# Altium schematic sheet driver4-8.SchDoc(driver4-8)
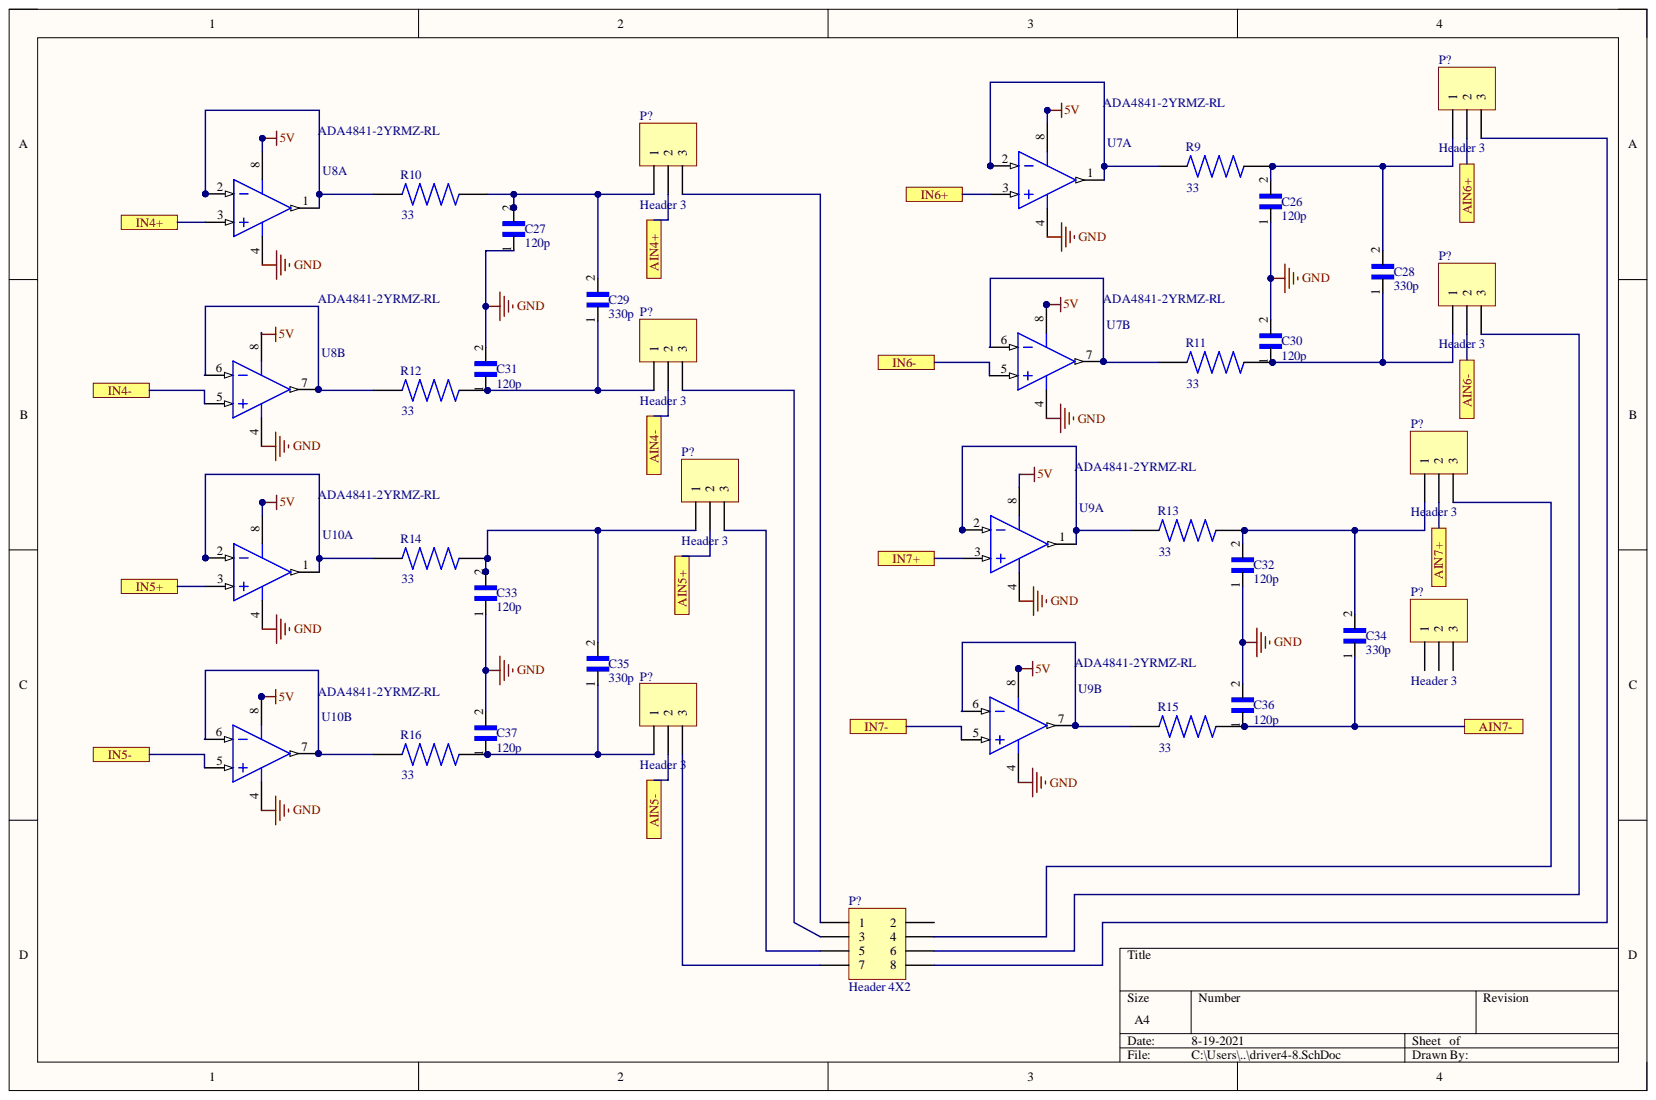
[diagram p0: driver4-8.SchDoc]

## Parts
C26  120p  [CAPC3216X94N]
C27  120p  [CAPC3216X94N]
C28  330p  [CAPC3216X94N]
C29  330p  [CAPC3216X94N]
C30  120p  [CAPC3216X94N]
C31  120p  [CAPC3216X94N]
C32  120p  [CAPC3216X94N]
C33  120p  [CAPC3216X94N]
C34  330p  [CAPC3216X94N]
C35  330p  [CAPC3216X94N]
C36  120p  [CAPC3216X94N]
C37  120p  [CAPC3216X94N]
P?  Header 3  [HDR1X3]
P?  Header 3  [HDR1X3]
P?  Header 3  [HDR1X3]
P?  Header 3  [HDR1X3]
P?  Header 3  [HDR1X3]
P?  Header 3  [HDR1X3]
P?  Header 3  [HDR1X3]
P?  Header 3  [HDR1X3]
P?  Header 4X2  [HDR2X4]
R9  33  [RESC3116X75N]
R10  33  [RESC3116X75N]
R11  33  [RESC3116X75N]
R12  33  [RESC3116X75N]
R13  33  [RESC3116X75N]
R14  33  [RESC3116X75N]
R15  33  [RESC3116X75N]
R16  33  [RESC3116X75N]
U7  ADA4841-2YRMZ-RL  [ADI-RM-8_M]  (parts A,B)
U8  ADA4841-2YRMZ-RL  [ADI-RM-8_M]  (parts A,B)
U9  ADA4841-2YRMZ-RL  [ADI-RM-8_M]  (parts A,B)
U10  ADA4841-2YRMZ-RL  [ADI-RM-8_M]  (parts A,B)

## Ports
AIN4+, AIN4-, AIN5+, AIN5-, AIN6+, AIN6-, AIN7+, AIN7-, IN4+, IN4-, IN5+, IN5-, IN6+, IN6-, IN7+, IN7-

## Nets
5V: U7.8 U8.8 U9.8 U10.8
GND: C26.1 C27.1 C30.2 C31.2 C32.1 C33.1 C36.2 C37.2 U7.4 U8.4 U9.4 U10.4
AIN4+: P?.2 ; port AIN4+
AIN4-: P?.2 ; port AIN4-
AIN5+: P?.2 ; port AIN5+
AIN5-: P?.2 ; port AIN5-
AIN6+: P?.2 ; port AIN6+
AIN6-: P?.2 ; port AIN6-
AIN7+: P?.2 ; port AIN7+
AIN7-: C34.1 C36.1 R15.2 ; port AIN7-
IN4+: U8.3 ; port IN4+
IN4-: U8.5 ; port IN4-
IN5+: U10.3 ; port IN5+
IN5-: U10.5 ; port IN5-
IN6+: U7.3 ; port IN6+
IN6-: U7.5 ; port IN6-
IN7+: U9.3 ; port IN7+
IN7-: U9.5 ; port IN7-
NetC26_2: C26.2 C28.2 P?.1 R9.2
NetC27_2: C27.2 C29.2 P?.1 R10.2
NetC28_1: C28.1 C30.1 P?.1 R11.2
NetC29_1: C29.1 C31.1 P?.1 R12.2
NetC32_2: C32.2 C34.2 P?.1 R13.2
NetC33_2: C33.2 C35.2 P?.1 R14.2
NetC35_1: C35.1 C37.1 P?.1 R16.2
NetP?_1: P?.1 P?.3
NetP?_3: P?.3 P?.4 P?.5 P?.6 P?.7 P?.8
NetR9_1: R9.1 U7.1 U7.2
NetR10_1: R10.1 U8.1 U8.2
NetR11_1: R11.1 U7.6 U7.7
NetR12_1: R12.1 U8.6 U8.7
NetR13_1: R13.1 U9.1 U9.2
NetR14_1: R14.1 U10.1 U10.2
NetR15_1: R15.1 U9.6 U9.7
NetR16_1: R16.1 U10.6 U10.7

## Library data of the parts
C26 C27 C30-C33 C36 C37 = 12061A121JAT2A: CERAMIC CAPACITOR 120PF 100V, C0G, 5%, 1206; MF: {'manufacturer': u'AVX'}; Availability: Unavailable; Component Kind: Standard; Library Name: 12061A121JAT2A.SchLib; MP: 12061A121JAT2A; Package: None; Price: None
C28 C29 C34 C35 = 12065A331JAT2A: Ceramic Multilayer Capacitor; Capacitor Type:General Purpose; Capacitance:330pF; Capacitance Tolerance:Â± 5%; Voltage Rating:50VDC; Capacitor Dielectric Type:Multilayer Ceramic; Package/Case:1206; Termination Type:SMD ;RoHS Compliant: Yes; MF: {'manufacturer': u'AVX'}; Availability: Unavailable; Component Kind: Standard; Library Name: 12065A331JAT2A.SchLib; MP: 12065A331JAT2A; Package: None; Price: None
P? P? P? P? P? P? P? P? = Header 3: Header, 3-Pin; Component Kind: Standard; LatestRevisionDate: 17-Jul-2002; LatestRevisionNote: Re-released for DXP Platform.; Library Name: Miscellaneous Connectors.IntLib; Signal Integrity: Connector; Publisher: Altium Limited
P? = Header 4X2: Header, 4-Pin, Dual row; Component Kind: Standard; LatestRevisionDate: 17-Jul-2002; LatestRevisionNote: Re-released for DXP Platform.; Library Name: Miscellaneous Connectors.IntLib; Signal Integrity: Connector; Publisher: Altium Limited
R9-R16 = RTAN1206BKE33R0: MF: {'manufacturer': u'Stackpole Electronics Inc'}; Availability: Unavailable; Component Kind: Standard; Library Name: RTAN1206BKE33R0.SchLib; MP: RTAN1206BKE33R0; Package: None; Price: None
U7-U10 = CMP-0384-01677-1: Double Channel Low Wide Band Noise Operational Amplifier, 80 MHz BW, 13 V/us SR, Industrial, 8-pin SOP (RM-8), Reel; Manufacturer: Analog Devices; Amplifiers Per Package: 2; Input Bias Current: 3uA; Input Offset Voltage: 40uV; Iq/Amp: 1.5mA; Slew Rate: 13V/us; Small Signal Bandwidth: 80MHz; V Span Max: 12V; V Span Min: 2.7V; Code_JEDEC: MO-187-AA; Component Kind: Standard; ComponentLink1Description: Manufacturer URL; ComponentLink1URL: http://www.analog.com/en/index.html; ComponentLink2Description: Datasheet; ComponentLink2URL: http://www.analog.com/static/imported-files/data_sheets/ADA4841-1_4841-2.pdf; ComponentLink3Description: Package Specification; ComponentLink3URL: http://www.analog.com/static/imported-files/packages/PKG_PDF/MSOP%28RM%29/RM_8.pdf; DatasheetVersion: Rev. E; Generic Part Number: ADA4841-2; Library Name: Altium Content Vault; Mounting Technology: Surface Mount; PackageDescription: 8-Pin Mini Small Outline Package, Body 3 x 3 mm, Pitch 0.65 mm; PackageReference: RM-8; PartNumber: ADA4841-2YRMZ-RL
